# Altium schematic sheet 11-M2_RCB_MUX.SchDoc(11-M2_RCB_MUX)
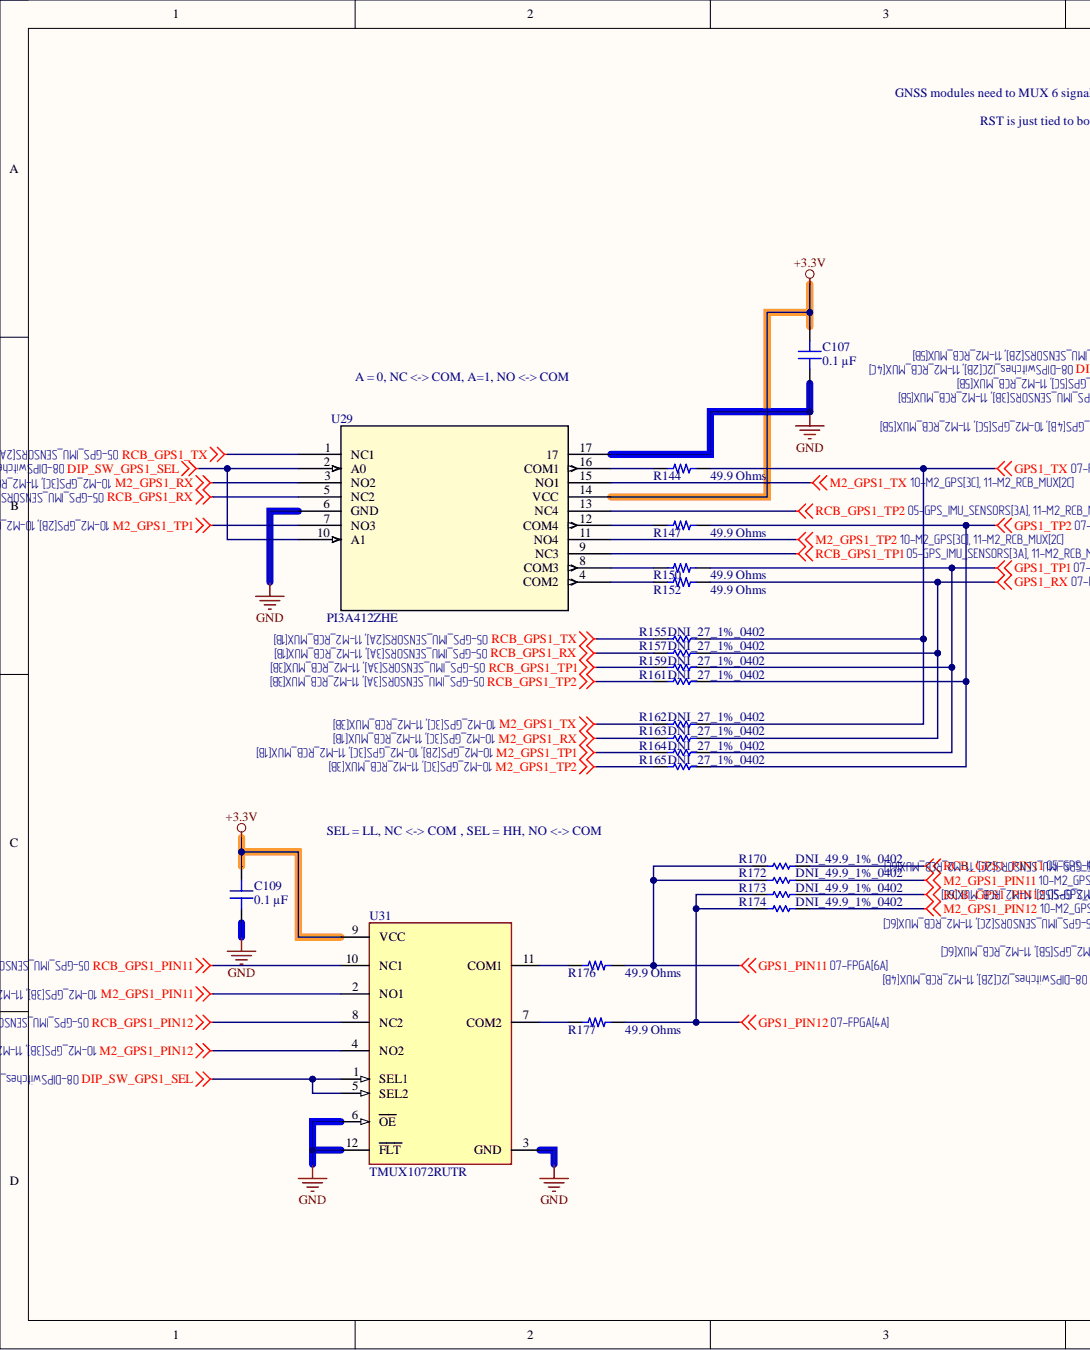
[diagram p0: 11-M2_RCB_MUX.SchDoc, left]
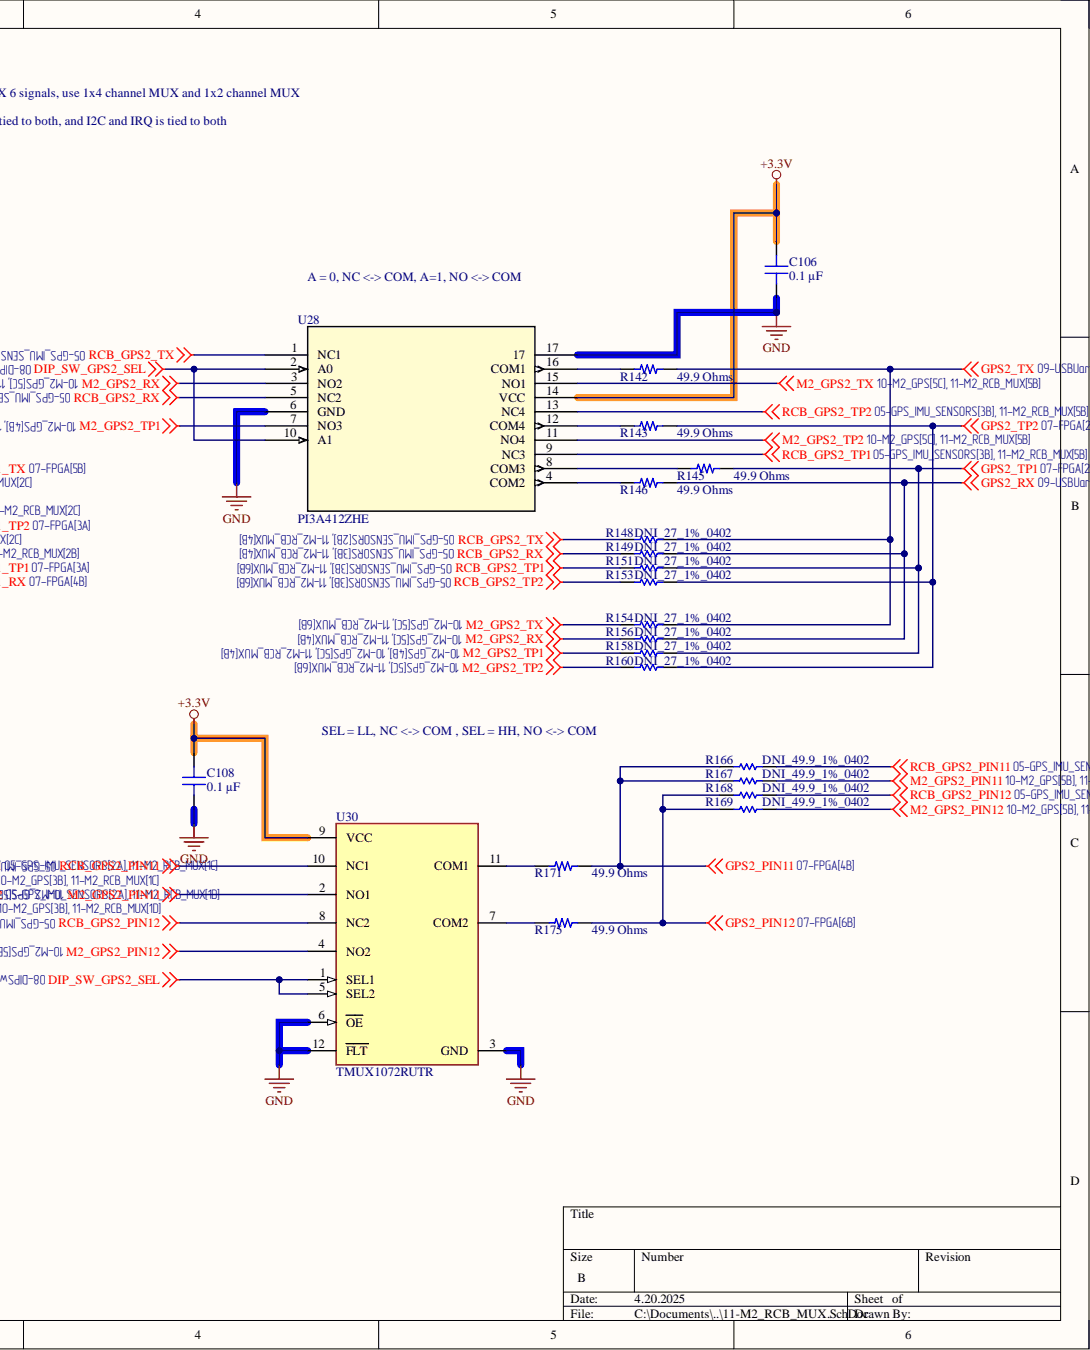
[diagram p1: 11-M2_RCB_MUX.SchDoc, right]

## Parts
C106  0.1 µF  [CAP 0402_1005]
C107  0.1 µF  [CAP 0402_1005]
C108  0.1 µF  [CAP 0402_1005]
C109  0.1 µF  [CAP 0402_1005]
R142  49.9 Ohms  [YAGEO RES 0402_1005]
R143  49.9 Ohms  [YAGEO RES 0402_1005]
R144  49.9 Ohms  [YAGEO RES 0402_1005]
R145  49.9 Ohms  [YAGEO RES 0402_1005]
R146  49.9 Ohms  [YAGEO RES 0402_1005]
R147  49.9 Ohms  [YAGEO RES 0402_1005]
R148  DNI_27_1%_0402  [RESC1005X40X25NL05T05]  (no BOM)
R149  DNI_27_1%_0402  [RESC1005X40X25NL05T05]  (no BOM)
R150  49.9 Ohms  [YAGEO RES 0402_1005]
R151  DNI_27_1%_0402  [RESC1005X40X25NL05T05]  (no BOM)
R152  49.9 Ohms  [YAGEO RES 0402_1005]
R153  DNI_27_1%_0402  [RESC1005X40X25NL05T05]  (no BOM)
R154  DNI_27_1%_0402  [RESC1005X40X25NL05T05]  (no BOM)
R155  DNI_27_1%_0402  [RESC1005X40X25NL05T05]  (no BOM)
R156  DNI_27_1%_0402  [RESC1005X40X25NL05T05]  (no BOM)
R157  DNI_27_1%_0402  [RESC1005X40X25NL05T05]  (no BOM)
R158  DNI_27_1%_0402  [RESC1005X40X25NL05T05]  (no BOM)
R159  DNI_27_1%_0402  [RESC1005X40X25NL05T05]  (no BOM)
R160  DNI_27_1%_0402  [RESC1005X40X25NL05T05]  (no BOM)
R161  DNI_27_1%_0402  [RESC1005X40X25NL05T05]  (no BOM)
R162  DNI_27_1%_0402  [RESC1005X40X25NL05T05]  (no BOM)
R163  DNI_27_1%_0402  [RESC1005X40X25NL05T05]  (no BOM)
R164  DNI_27_1%_0402  [RESC1005X40X25NL05T05]  (no BOM)
R165  DNI_27_1%_0402  [RESC1005X40X25NL05T05]  (no BOM)
R166  DNI_49.9_1%_0402  [RESC1005X40X25LL05T10]  (no BOM)
R167  DNI_49.9_1%_0402  [RESC1005X40X25LL05T10]  (no BOM)
R168  DNI_49.9_1%_0402  [RESC1005X40X25LL05T10]  (no BOM)
R169  DNI_49.9_1%_0402  [RESC1005X40X25LL05T10]  (no BOM)
R170  DNI_49.9_1%_0402  [RESC1005X40X25LL05T10]  (no BOM)
R171  49.9 Ohms  [YAGEO RES 0402_1005]
R172  DNI_49.9_1%_0402  [RESC1005X40X25LL05T10]  (no BOM)
R173  DNI_49.9_1%_0402  [RESC1005X40X25LL05T10]  (no BOM)
R174  DNI_49.9_1%_0402  [RESC1005X40X25LL05T10]  (no BOM)
R175  49.9 Ohms  [YAGEO RES 0402_1005]
R176  49.9 Ohms  [YAGEO RES 0402_1005]
R177  49.9 Ohms  [YAGEO RES 0402_1005]
U28  PI3A412ZHE  [ZH16_PER]
U29  PI3A412ZHE  [ZH16_PER]
U30  TMUX1072RUTR  [FP-RUT0012A-MFG]
U31  TMUX1072RUTR  [FP-RUT0012A-MFG]

## Nets
+3.3V: C106.2 C107.2 C108.1 C109.1 U28.14(VCC) U29.14(VCC) U30.9(VCC) U31.9(VCC)
DIP_SW_GPS1_SEL: U29.2(A0) U29.10(A1) U31.1(SEL1) U31.5(SEL2)
DIP_SW_GPS2_SEL: U28.2(A0) U28.10(A1) U30.1(SEL1) U30.5(SEL2)
GND: C106.1 C107.1 C108.2 C109.2 U28.6(GND) U28.17 U29.6(GND) U29.17 U30.3(GND) U30.6(OE) U30.12(FLT) U31.3(GND) U31.6(OE) U31.12(FLT)
GPS1_PIN11: R170.1 R172.1 R176.2
GPS1_PIN12: R173.1 R174.1 R177.2
GPS1_RX: R152.2 R157.2 R163.2
GPS1_TP1: R150.2 R159.2 R164.2
GPS1_TP2: R147.2 R161.2 R165.2
GPS1_TX: R144.2 R155.2 R162.2
GPS2_PIN11: R166.1 R167.1 R171.2
GPS2_PIN12: R168.1 R169.1 R175.2
GPS2_RX: R146.2 R149.2 R156.2
GPS2_TP1: R145.2 R151.2 R158.2
GPS2_TP2: R143.2 R153.2 R160.2
GPS2_TX: R142.2 R148.2 R154.2
M2_GPS1_PIN11: R172.2 U31.2(NO1)
M2_GPS1_PIN12: R174.2 U31.4(NO2)
M2_GPS1_RX: R163.1 U29.3(NO2)
M2_GPS1_TP1: R164.1 U29.7(NO3)
M2_GPS1_TP2: R165.1 U29.11(NO4)
M2_GPS1_TX: R162.1 U29.15(NO1)
M2_GPS2_PIN11: R167.2 U30.2(NO1)
M2_GPS2_PIN12: R169.2 U30.4(NO2)
M2_GPS2_RX: R156.1 U28.3(NO2)
M2_GPS2_TP1: R158.1 U28.7(NO3)
M2_GPS2_TP2: R160.1 U28.11(NO4)
M2_GPS2_TX: R154.1 U28.15(NO1)
RCB_GPS1_PIN11: R170.2 U31.10(NC1)
RCB_GPS1_PIN12: R173.2 U31.8(NC2)
RCB_GPS1_RX: R157.1 U29.5(NC2)
RCB_GPS1_TP1: R159.1 U29.9(NC3)
RCB_GPS1_TP2: R161.1 U29.13(NC4)
RCB_GPS1_TX: R155.1 U29.1(NC1)
RCB_GPS2_PIN11: R166.2 U30.10(NC1)
RCB_GPS2_PIN12: R168.2 U30.8(NC2)
RCB_GPS2_RX: R149.1 U28.5(NC2)
RCB_GPS2_TP1: R151.1 U28.9(NC3)
RCB_GPS2_TP2: R153.1 U28.13(NC4)
RCB_GPS2_TX: R148.1 U28.1(NC1)
NetR142_1: R142.1 U28.16(COM1)
NetR143_1: R143.1 U28.12(COM4)
NetR144_1: R144.1 U29.16(COM1)
NetR145_1: R145.1 U28.8(COM3)
NetR146_1: R146.1 U28.4(COM2)
NetR147_1: R147.1 U29.12(COM4)
NetR150_1: R150.1 U29.8(COM3)
NetR152_1: R152.1 U29.4(COM2)
NetR171_1: R171.1 U30.11(COM1)
NetR175_1: R175.1 U30.7(COM2)
NetR176_1: R176.1 U31.11(COM1)
NetR177_1: R177.1 U31.7(COM2)

## Library data of the parts
C106-C109 = CC0402KRX7R9BB104: CAP CER 0.1UF 50V X7R 0402; manufacturer: Yageo; Database Table Name: Capacitors - Ceramic - 0402; Tolerance: ±10%; Applications: General Purpose; Capacitance: 0.1 µF; Component Kind: Standard; componentlink1description: Datasheet; componentlink1url: https://www.yageo.com/upload/media/product/productsearch/datasheet/mlcc/UPY-GPHC_X7R_6.3V-to-50V_18.pdf; componentlink2description: DigiKey Link; componentlink2url: https://www.digikey.com/en/products/detail/yageo/CC0402KRX7R9BB104/5883371; Device Package: 0402 (1005 Metric); lastupdated: 09.02.2024 20:04:27; Library Name: Celestial Altium Library - altium_library.DbLib; Lifecycle Status: Active; Minimum Order: 10; Mounting Type: Surface Mount, MLCC; Operating Temperature: -55°C ~ 125°C; Package / Case: 0402 (1005 Metric); packaging: TapeAndReel; Part Number: CC0402KRX7R9BB104; Part Status: Active; partid: 8451; price: 0.01; series: CC; Size / Dimension: 0.039" L x 0.020" W (1.00mm x 0.50mm); Supplier 1: DigiKey; Supplier Part Number 1: 311-3566-1-ND; Temperature Coefficient: X7R; Thickness (Max): 0.022" (0.55mm); Value: 0.1 µF; Voltage - Rated: 50V
R142-R147 R150 R152 R171 R175-R177 = RC0402FR-0749R9L: RES 49.9 OHM 1% 1/16W 0402; Manufacturer: Yageo; Database Table Name: Resistors - Surface Mount - 0402; Power (Watts): 0.063W, 1/16W; Temperature Coefficient: ±100ppm/°C; Component Kind: Standard; ComponentLink1Description: Datasheet; ComponentLink1URL: https://www.yageo.com/upload/media/product/productsearch/datasheet/rchip/PYu-RC_Group_51_RoHS_L_10.pdf; ComponentLink2Description: DigiKey Link; ComponentLink2URL: https://www.digikey.com/en/products/detail/yageo/RC0402FR-0749R9L/726621; Composition: Thick Film; Device Package: 0402 (1005 Metric); Features: Moisture Resistant; Height - Seated (Max): 0.016" (0.40mm); LastUpdated: 25.07.2024 9:18:11; Library Name: Celestial Altium Library - altium_library.DbLib; Lifecycle Status: Active; Minimum Order: 1; Number of Terminations: 2; Operating Temperature: -55°C ~ 155°C; Package / Case: 0402 (1005 Metric); Packaging: CutTape; Part Number: RC0402FR-0749R9L; Part Status: Active; PartId: 17605; Price: 0.01; Resistance: 49.9 Ohms; Series: RC_L; Size / Dimension: 0.039" L x 0.020" W (1.00mm x 0.50mm); Supplier 1: DigiKey; Supplier Device Package: 0402; Supplier Part Number 1: 311-49.9LRCT-ND; Tolerance: ±1%; Value: 49.9 Ohms
R148 R149 R151 R153-R165 = CMP-2002-08434-1: Manufacturer: Vishay Dale; Part Number: CRCW040227R0FKED; Library Name: Altium Content Vault
R166-R170 R172-R174 = CMP-2002-07709-1: Manufacturer: Yageo / Phycomp; Part Number: RC0402FR-0749R9L; Library Name: Altium Content Vault
U28 U29 = PI3A412ZHE: No Description Available; Mfr_Name: Pericom; Component Kind: Standard; Library Name: PI3A412ZHE.SchLib; RefDes: RefDes; Type: Type
U30 U31 = CMP-04892-000114-1: IC SWITCH SPDT DUAL 12UQFN; -3db Bandwidth: 1.2GHz; Number of Channels: 2; On-State Resistance: 18R; Component Kind: Standard; Library Name: Altium Content Vault; Max Operating Temperature: 125°C; Min Operating Temperature: -40°C; Number of Circuits: 2; Number of Pins: 12; Packaging: Tape and Reel; RoHS Compliant: Yes
